# Altium schematic sheet powersupply.SchDoc(powersupply)
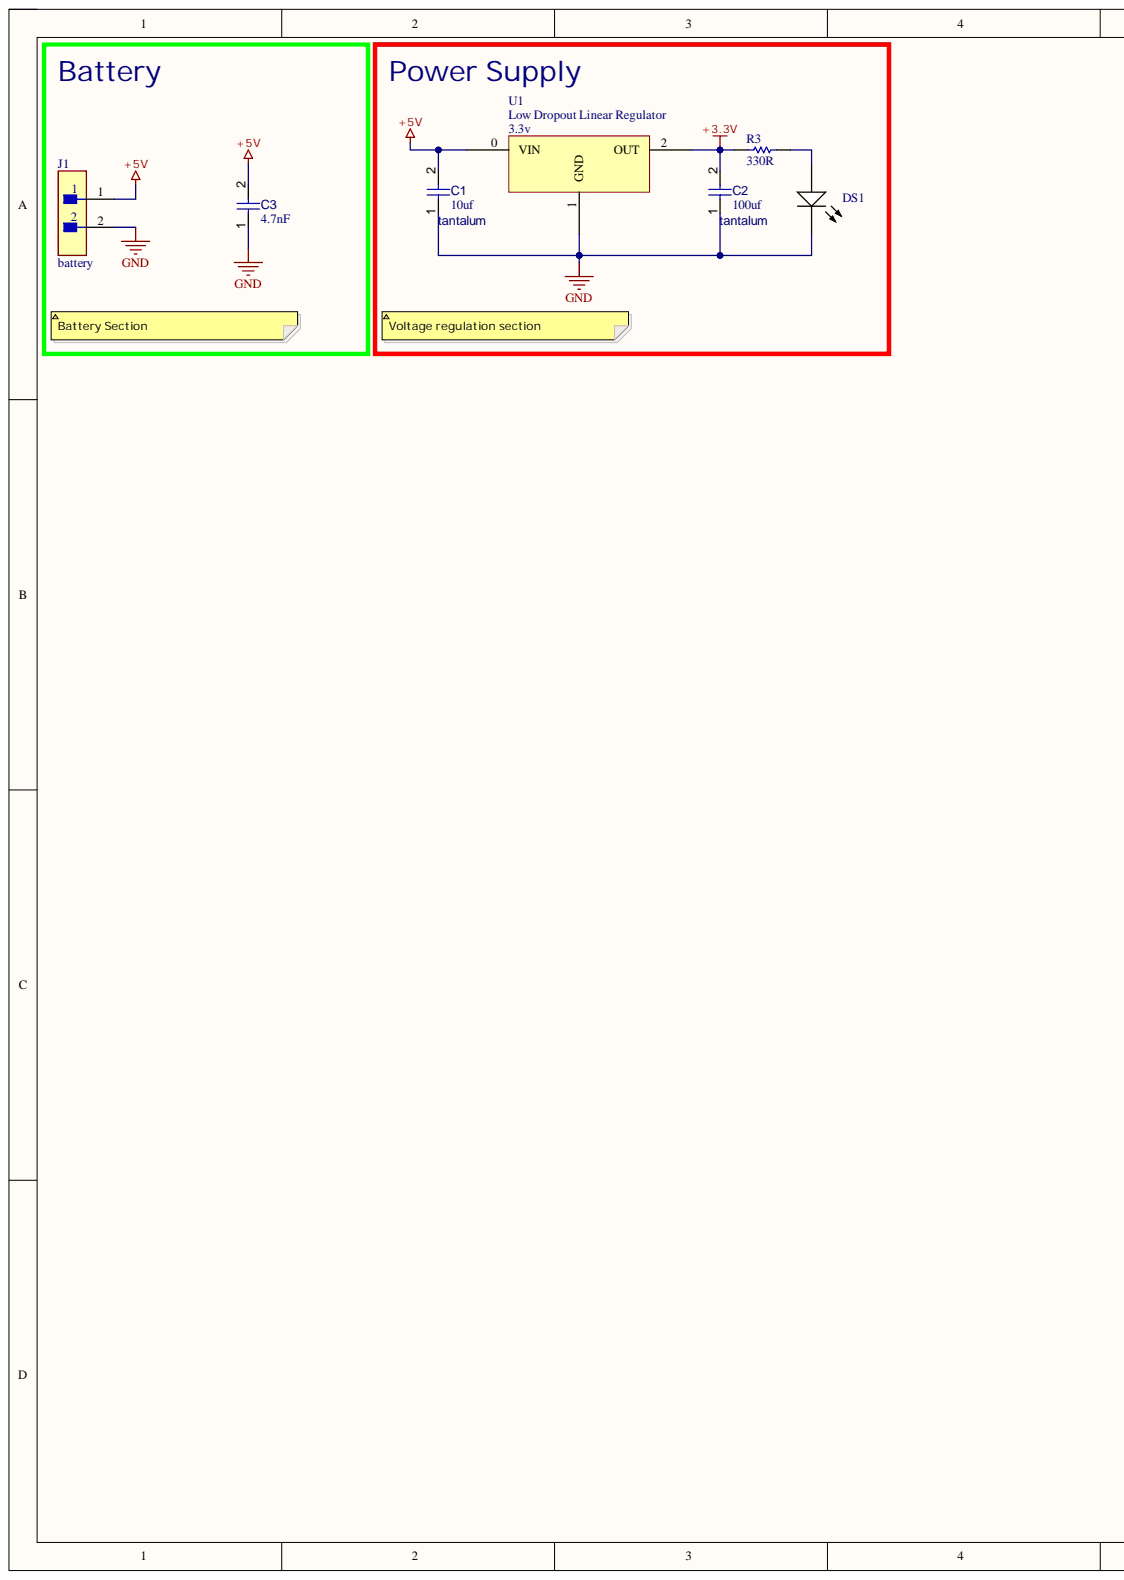
[diagram p0: powersupply.SchDoc, left]
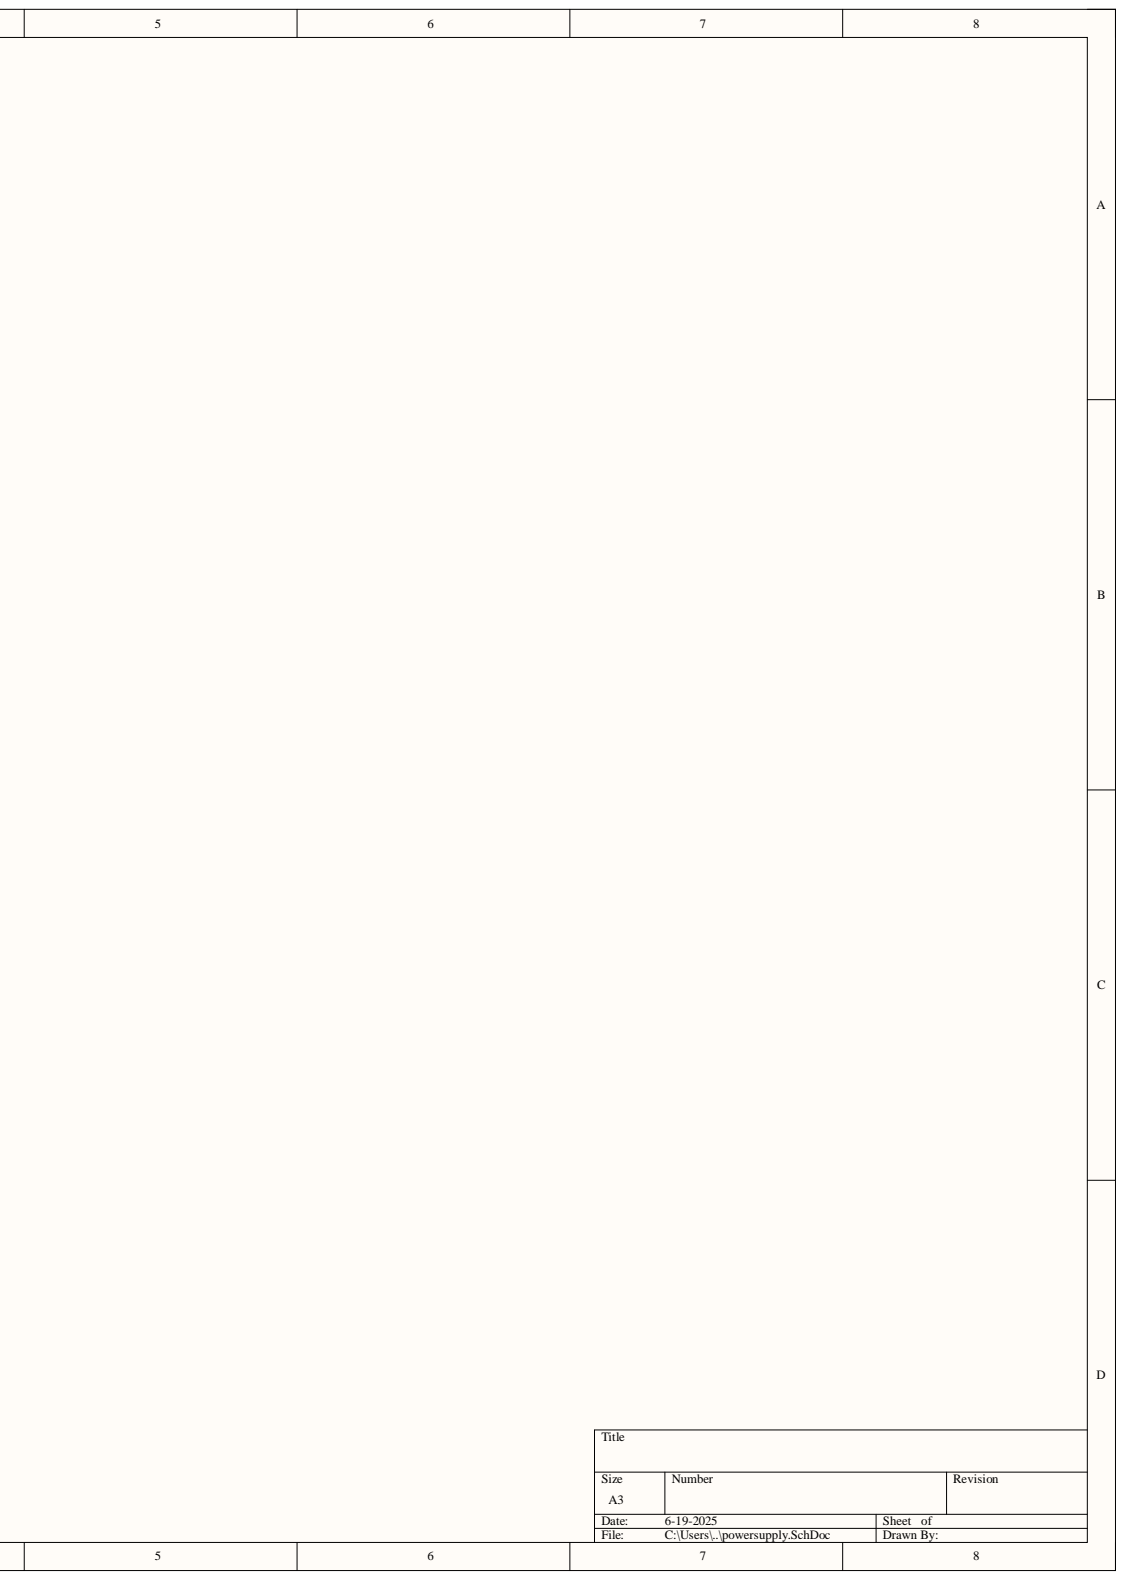
[diagram p1: powersupply.SchDoc, right]

## Parts
C1  tantalum  value 10uf  [CAPC0603(1608)100_L]
C2  tantalum  value 100uf  [CAPC0603(1608)100_L]
C3  value 4.7nF  [CAPC0603(1608)100_L]  (hidden comment: Capacitor 4.7µF +/-20% 10V 0603)
DS1  16-219A/T2D-AR2T1QY/3T  [EVRL-0402-2_V]  (value not drawn)
J1  battery  [FP-TMM-102-03-T-S-MFG]
R3  value 330R  [RESC1608X55X25ML10T15]  (hidden comment: Resistor 0R +/- 5% 0603 100 mW)
U1  Low Dropout Linear Regulator  Output Volatge: 3.3v  [4 pin SOT]

## Nets
+3.3V: C2.2 R3.1 U1.2
+5V: C1.2 C3.2 J1.1 U1.0
GND: C1.1 C2.1 C3.1 DS1.2 J1.2 U1.1
NetDS1_1: DS1.1 R3.2

## Library data of the parts
C1-C3 = CMP-001-00076-4: Chip Capacitor, 4.7µF +/-20%, 10V, 0603, Thickness 1 mm; Tolerance: 10%; Case/Package: 0603; Component Kind: Standard; Library Name: Altium Cloud; Max Operating Temperature: 85°C; Min Operating Temperature: -55°C; Mounting Technology: SMT; Pins: 2; Voltage Rating: 10V
DS1 = CMP-007-00000-2: Chip LED, White, 5 V, 10 mA, 30 mW, -40 to 85 degC, 2-Pin SMD (0402), RoHS, Tape and Reel; Manufacturer: Everlight Electronics; Forward Current: 5mA; Forward Voltage: 2.6V; Luminous Intensity: 360mcd; Power: 30mW; Viewing Angle: 130degrees; Case/Package: 0402; Component Kind: Standard; Datasheet URL: http://www.everlight.com/file/ProductFile/16-219A-T2D-AR2T1QY-3T.pdf; Datasheet Version: Rev. 5, 04/2013; Illumination Colour: White; Library Name: Altium Cloud; Manufacturer URL: http://www.everlight.com/; Max Operating Temperature: 85°C; Min Operating Temperature: -40°C; Mounting Technology: SM; Package Description: 2-Pin Surface Mount Device, Body 1 x 0.5 mm; Package Quantity: 3000; Package Reference: 0402; Packaging: TapeandReel; RoHS Compliant: True
J1 = CMP-02766-001621-1: CONN HEADER VERT 2POS 2MM; Gender: Male; Max Current Rating: 3.9A; Max Voltage Rating (AC): 500V; Number of Contacts: 2; Color: Black; Component Kind: Standard; Connector Type: Header; Contact Finish - Mating: TIN; Contact Material: Bronze; Contact Mating Length: 0.157inch; Contact Plating: Tin; Contact Shape: Square; Contact Type: Male Pin; Depth: 1.97mm; Fastening Type: Pull; Housing Color: Black; Housing Material: Polymer; Insulation Color: Black; Insulation Material: Polymer; Lead Free: Lead Free; Length: 4mm; Library Name: Altium Content Vault; Max Operating Temperature: 105°C; Min Operating Temperature: -55°C; Mount: Through Hole; Number of Positions: 2; Number of Rows: 1; Orientation: Straight; Package Quantity: 1; Packaging: Bulk; Pitch: 2mm; Radiation Hardening: No; REACH SVHC: No SVHC; RoHS Compliant: Yes; Series: TMM; Termination: Solder
R3 = CMP-009-00006-5: Chip Resistor, 0 Ohm, 0.1 W, -55 to 155 degC, 0603 (1608 Metric); Power: 100mW; Voltage Rating: 50V; Case/Package: 0603; Component Kind: Standard; Library Name: Altium Cloud; Max Operating Temperature: 155°C; Min Operating Temperature: -55°C; Mounting Technology: SM; Pins: 2; RoHS Compliant: True; Tolerance: 5%
U1 = lm1117: Component Kind: Standard; ComponentLink1Description: Datasheet; ComponentLink1URL: https://www.ti.com/lit/ds/symlink/lm1117.pdf; Library Name: Schlib1.SchLib
